# Altium schematic sheet kegerator_mod.SchDoc
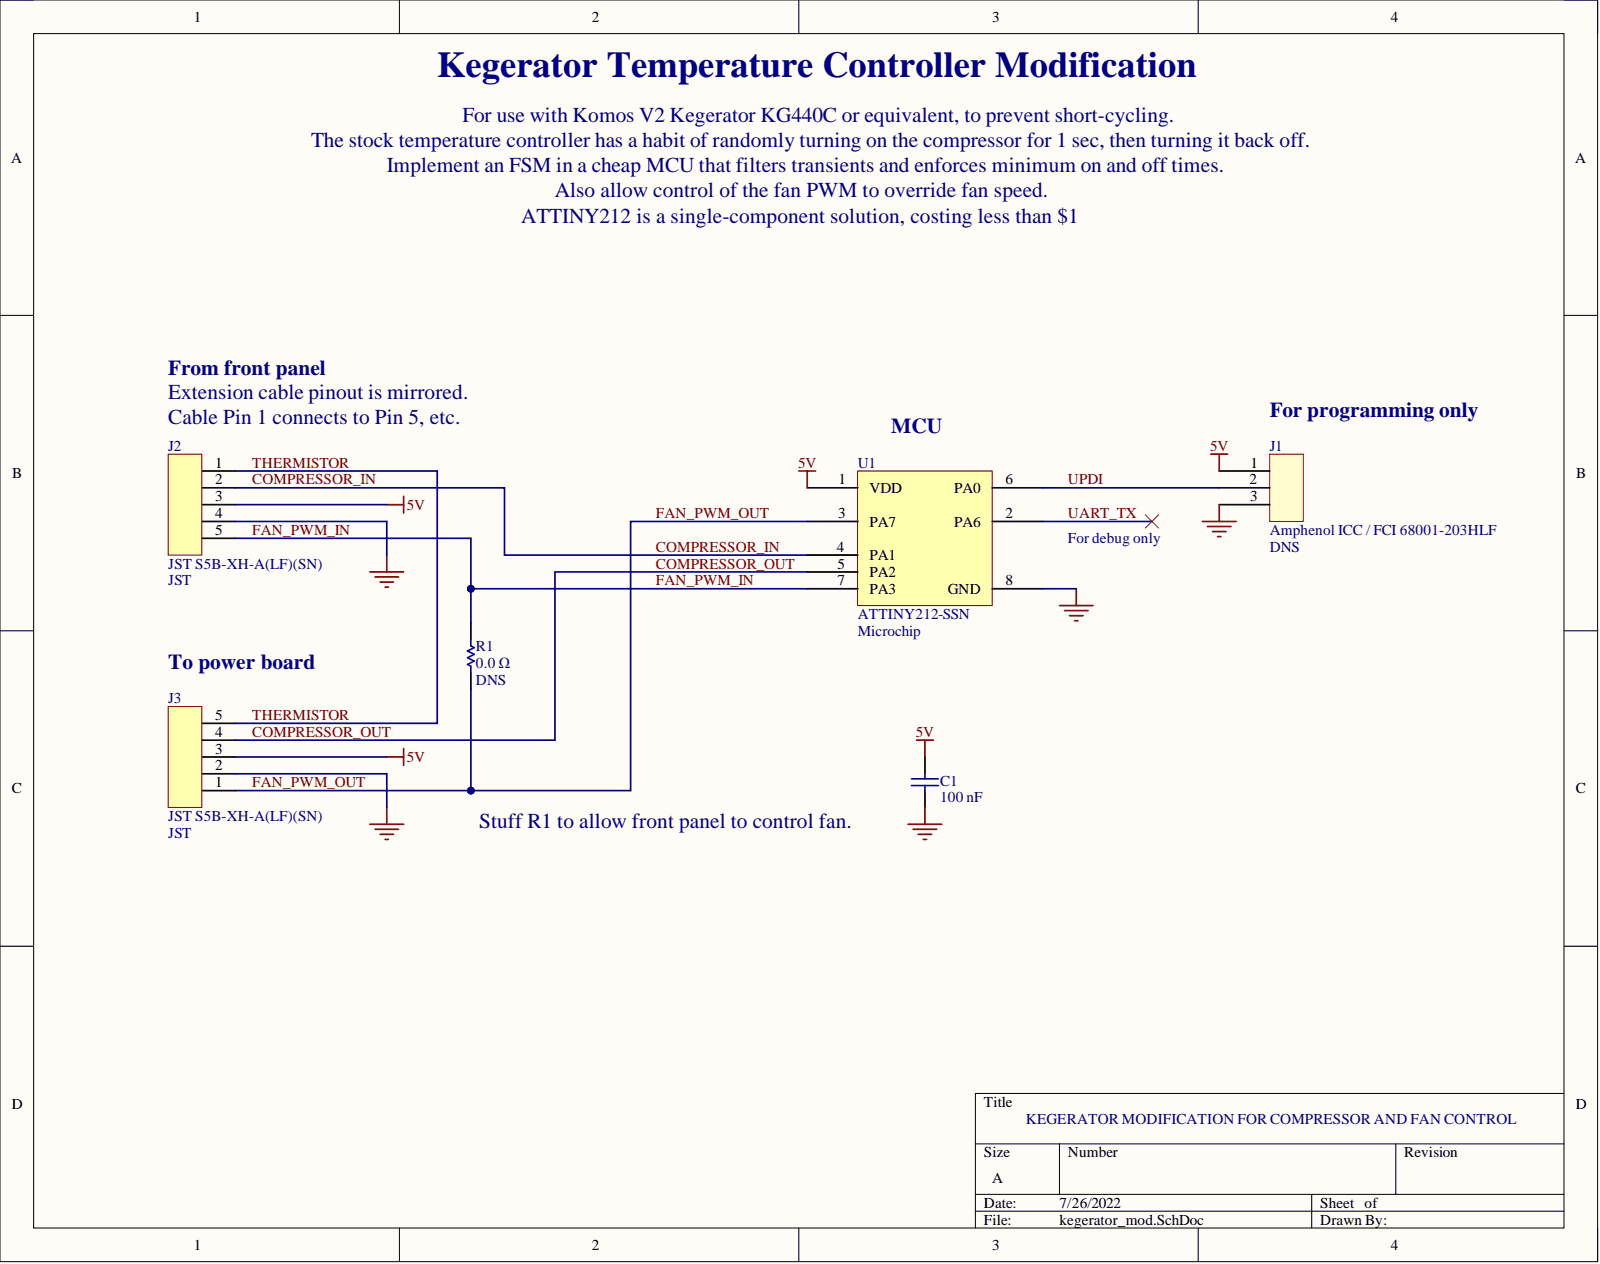
[diagram p0: kegerator_mod.SchDoc]

## Parts
C1  100 nF  [PCB-0002-00341-1]
J1  Amphenol ICC / FCI 68001-203HLF  Manufacturer: Amphenol  [Amphenol ICC _ FCI 68001-203HLF-Footprint-1]
J2  JST S5B-XH-A(LF)(SN)  Manufacturer: JST  [PCB-exb6w9s1vt6vn89jw8g4-8]
J3  JST S5B-XH-A(LF)(SN)  Manufacturer: JST  [PCB-exb6w9s1vt6vn89jw8g4-8]
R1  CRCW06030000Z0EB  [RESC1609X50X30LL10T20]  (value not drawn)
U1  ATTINY212-SSN  Manufacturer: Microchip  [PCB-k258i3o299ed8t2lz373-1]

## Nets
5V: C1.2 J1.1 J2.3 J3.3 U1.1(VDD)
GND: C1.1 J1.3 J2.4 J3.2 U1.8(GND)
COMPRESSOR_IN: J2.2 U1.4(PA1)
COMPRESSOR_OUT: J3.4 U1.5(PA2)
FAN_PWM_IN: J2.5 R1.2 U1.7(PA3)
FAN_PWM_OUT: J3.1 R1.1 U1.3(PA7)
THERMISTOR: J2.1 J3.5
UART_TX: U1.2(PA6)
UPDI: J1.2 U1.6(PA0)

## Library data of the parts
C1 = CMP-d20d1abbe4e70dca-6: Cap Ceramic 0.1uF 16V X5R 10% Pad SMD 0603 85°C Automotive T/R; Manufacturer: Taiyo Yuden; Manufacturer Part Number: EMK107BJ104KAHT; Lead-Free Status: Lead Free; Voltage Rating (DC): 16.0 V; Capacitance: 100 nF; Capacitance Tolerance: ±10%; Case/Package: 0603; Component Kind: Standard; Halogen Free Status: Halogen Free; Library Name: My Personal Space; Lifecycle Status: Active; Mounting Style: Surface Mount; Octopart URL: https://octopart.com/emk107bj104kaht-taiyo+yuden-29102988; OctopartID: d20d1abbe4e70dca; Packaging: Reel; RoHS: Compliant
J1 = CMP-7932b55a3e59a6f5-1: Conn Unshrouded Header HDR 3 POS 2.54mm Solder ST Top Entry Thru-Hole BergStik® Bulk; Gender: Male; Lead-Free Status: Lead Free; Number of Contacts: 3; Component Kind: Standard; Contact Material: Phosphor Bronze; Contact Plating: Gold over Nickel, Gold; DNS: DNS; Library Name: My Personal Space; Manufacturer Part Number: 68001-203HLF; Mounting Style: Through Hole; Number of Positions: 3; Number of Rows: 1; Octopart Url: https://octopart.com/68001-203hlf-amphenol+icc+%2F+fci-90333709; OctopartID: 7932b55a3e59a6f5; Packaging: Bulk; Pin Pitch: 2.54 mm; RoHS: Compliant
J2 J3 = CMP-b2367b84c4646df1-18: HEADER, RIGHT ANGLE, 5WAY; Connector Type: Wire-to-Board; Gender: Pin; Ways, No. of: 5; Lead Spacing: 2.5mm; Terminat...; Component Kind: Standard; Library Name: My Personal Space; Manufacturer Part Number: JST S5B-XH-A(LF)(SN)
R1 = CMP-1906891-2: Thick Film Resistors - SMD 1/10watt ZEROohm Jumper; Manufacturer: Vishay Dale; Lead-Free Status: Lead Free; Power Rating: 0.1 W; Resistance: 0.0 Ω; Voltage Rating (DC): 75.0 V; Case/Package: 0603; Component Kind: Standard; DNS: DNS; Library Name: My Personal Space; Manufacturer Part Number: CRCW06030000Z0EB; Number of Pins: 2; Octopart Url: https://octopart.com/crcw06030000z0eb-vishay-42505985; OctopartID: 61d7aa55d3b0be10; Packaging: Cut Tape (CT); RoHS: Compliant; Size-Length: 0.00155 m; Size-Width: 0.00085 m
U1 = CMP-9c68508ee9c943af-1: Microcontroller with Core Independent Peripherals and picoPower Technology 8-Bit AVR RISC 2KB Flash 8-Pin SOIC; Component Kind: Standard; Library Name: My Personal Space; Lifecycle Status: Not Listed by Manufacturer; Manufacturer Part Number: ATTINY212-SSN; Octopart URL: https://octopart.com/attiny212-ssn-microchip-99373255; OctopartID: 9c68508ee9c943af; Simulation: SIM-gwrsqqab1eoy56ourpri-1
